# Altium schematic sheet Communication.SchDoc(Communication)
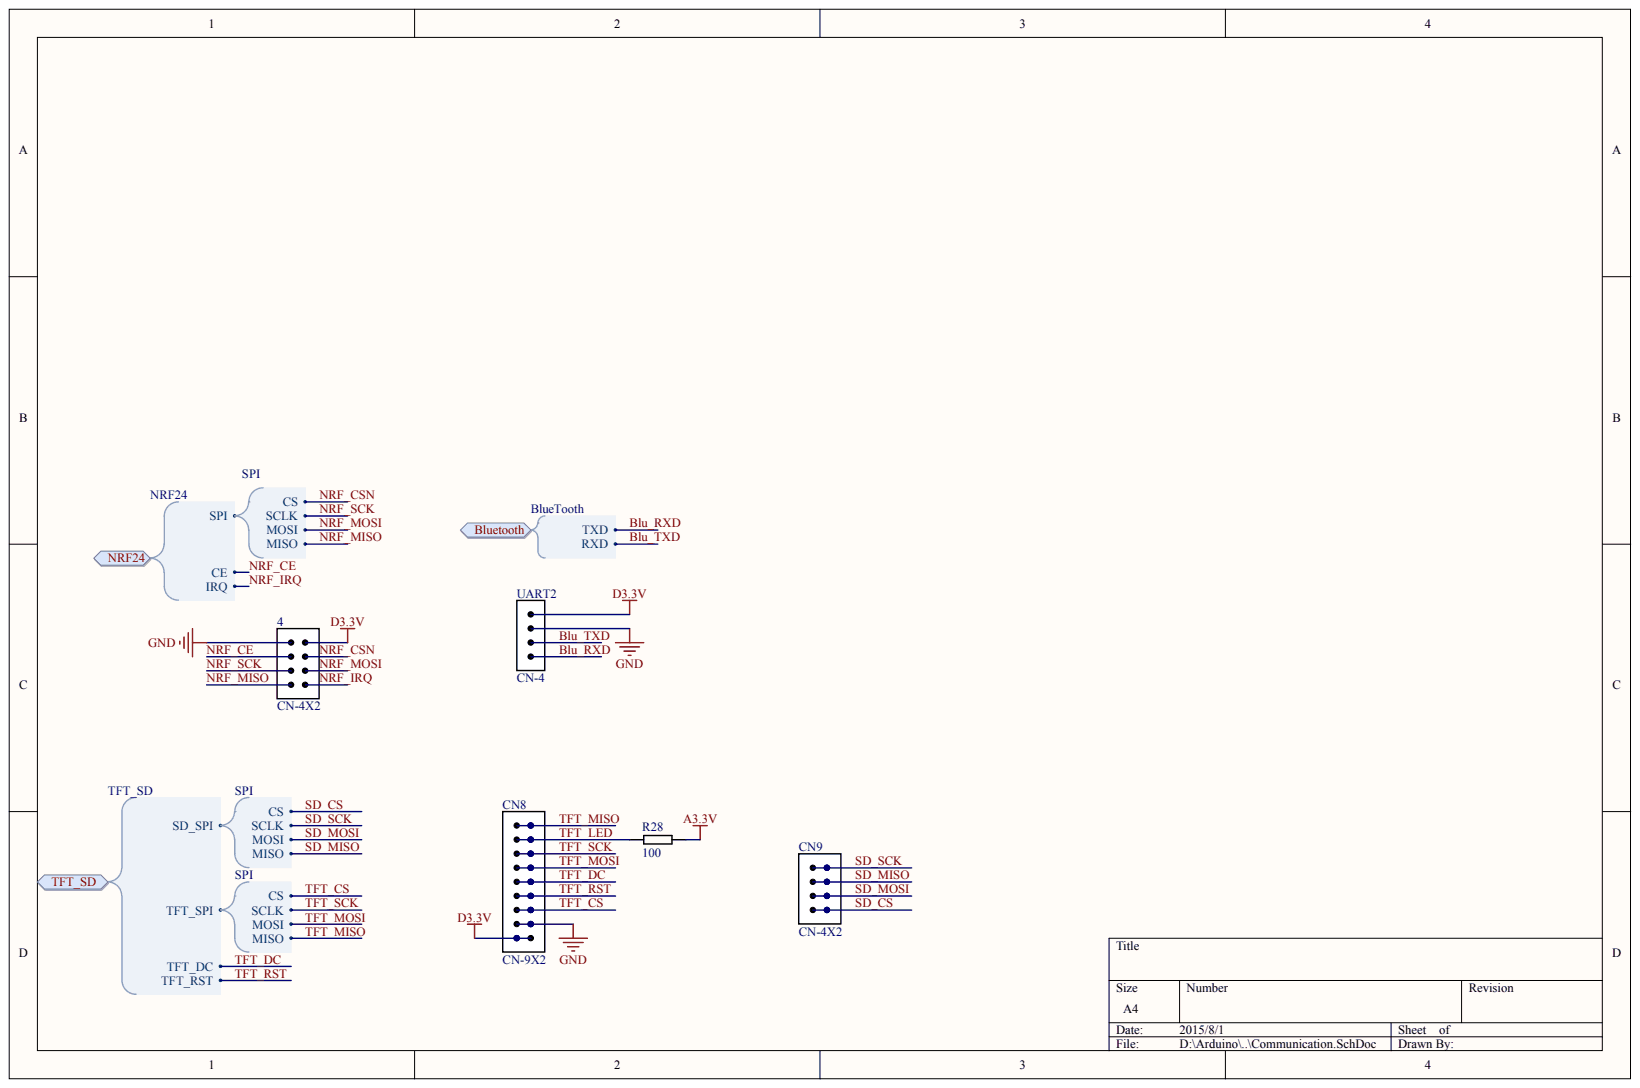
[diagram p0: Communication.SchDoc]

## Parts
4  CN-4X2
CN8  CN-9X2
CN9  CN-4X2
R28  100
UART2  CN-4

## Ports
BLUETOOTH, BLUETOOTH.RXD, BLUETOOTH.TXD, NRF24, NRF24.CE, NRF24.IRQ, NRF24.SPI, NRF24.SPI.CS, NRF24.SPI.MISO, NRF24.SPI.MOSI, NRF24.SPI.SCLK, TFT_SD, TFT_SD.SD_SPI.CS, TFT_SD.SD_SPI.MISO, TFT_SD.SD_SPI.MOSI, TFT_SD.SD_SPI.SCLK, TFT_SD.TFT_DC, TFT_SD.TFT_RST, TFT_SD.TFT_SPI.CS, TFT_SD.TFT_SPI.MISO, TFT_SD.TFT_SPI.MOSI, TFT_SD.TFT_SPI.SCLK

## Nets
A3.3V: R28.2
D3.3V: 4.2 CN8.17 CN8.18 UART2.1
GND: 4.1 CN8.15 CN8.16 UART2.2
BLU_RXD: UART2.4 ; port BLUETOOTH
BLU_TXD: UART2.3 ; port BLUETOOTH
NRF_CE: 4.3 ; port NRF24
NRF_CSN: 4.4 ; port NRF24
NRF_IRQ: 4.8 ; port NRF24
NRF_MISO: 4.7 ; port NRF24
NRF_MOSI: 4.6 ; port NRF24
NRF_SCK: 4.5 ; port NRF24
SD_CS: CN9.7 CN9.8 ; port TFT_SD
SD_MISO: CN9.3 CN9.4 ; port TFT_SD
SD_MOSI: CN9.5 CN9.6 ; port TFT_SD
SD_SCK: CN9.1 CN9.2 ; port TFT_SD
TFT_CS: CN8.13 CN8.14 ; port TFT_SD
TFT_DC: CN8.9 CN8.10 ; port TFT_SD
TFT_LED: CN8.3 CN8.4 R28.1
TFT_MISO: CN8.1 CN8.2 ; port TFT_SD
TFT_MOSI: CN8.7 CN8.8 ; port TFT_SD
TFT_RST: CN8.11 CN8.12 ; port TFT_SD
TFT_SCK: CN8.5 CN8.6 ; port TFT_SD
